# Altium schematic sheet cpu.SchDoc
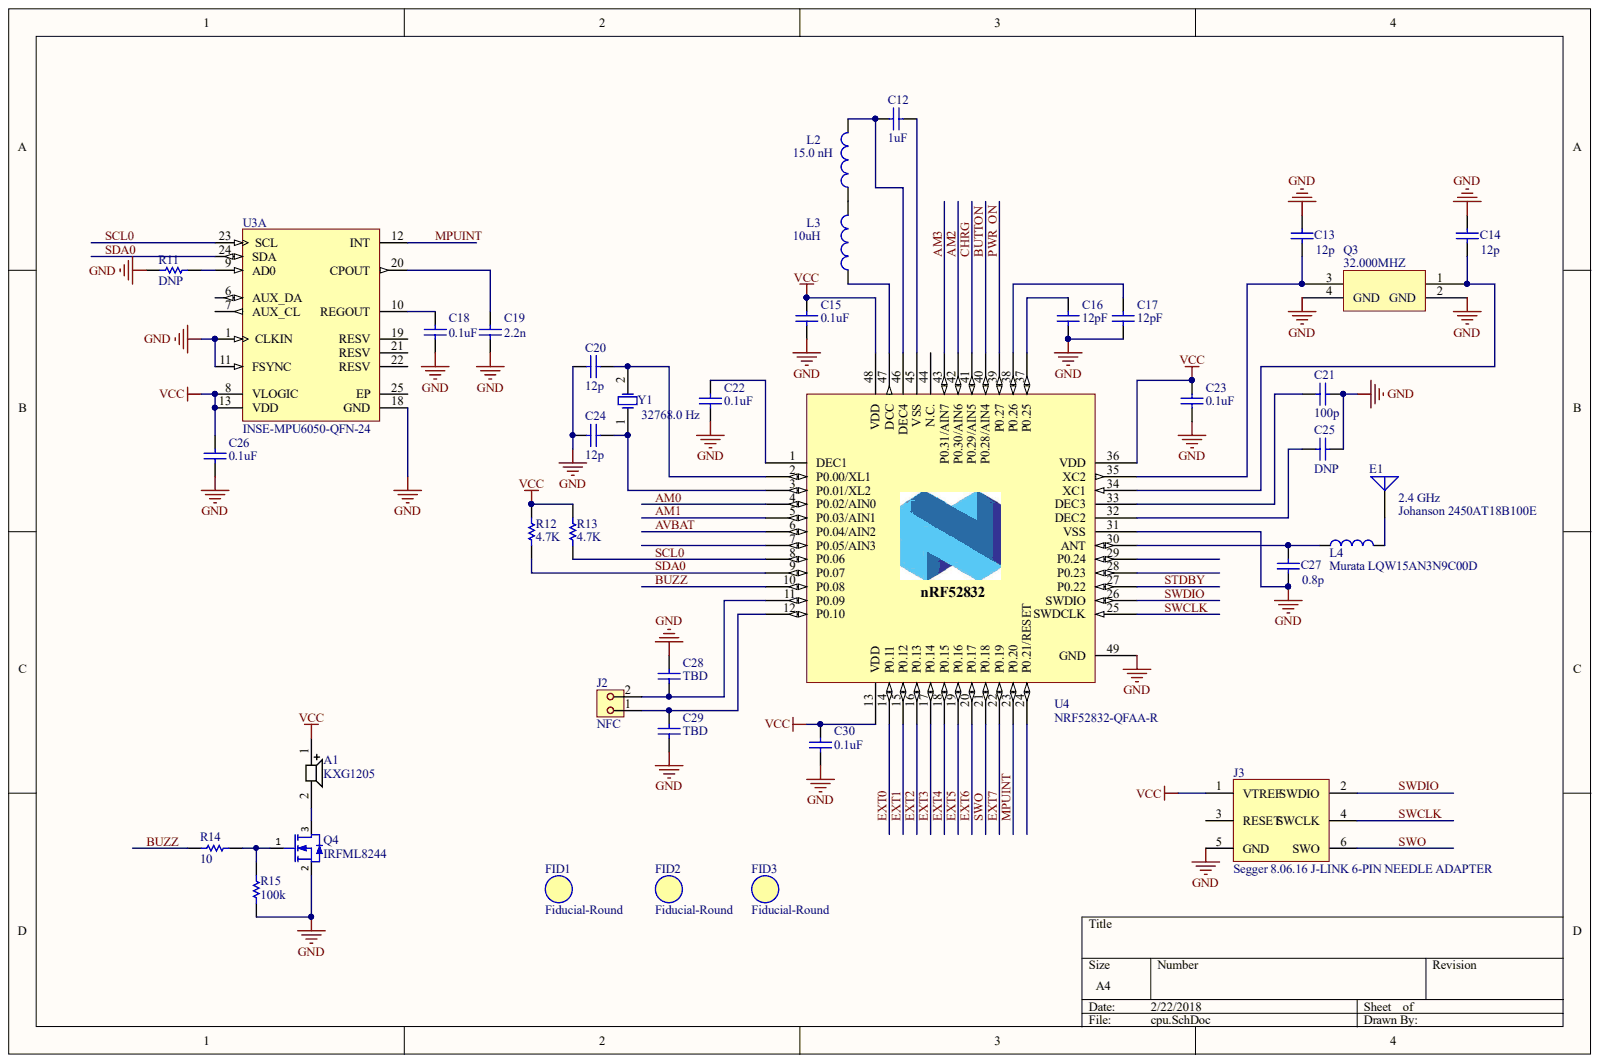
[diagram p0: cpu.SchDoc]

## Parts
A1  KXG1205  [PCB-wpqtvrz6t98vuyi79ns2-1]
C12  Capacitance: 1uF  [CAPC1608X90X35LL15T15]  (hidden comment: AVX Interconnect / Elco 06036D105KAT2A)
C13  Capacitance: 12p  [CAPC1005X56X25ML10T15]  (hidden comment: AVX Interconnect / Elco 04025A120JAT2A)
C14  Capacitance: 12p  [CAPC1005X56X25ML10T15]  (hidden comment: AVX Interconnect / Elco 04025A120JAT2A)
C15  Capacitance: 0.1uF  [CAPC1608X90X35LL15T15]  (hidden comment: AVX Interconnect / Elco 06036D105KAT2A)
C16  Capacitance: 12pF  [CAPC1608X90X35LL15T15]  (hidden comment: AVX Interconnect / Elco 06036D105KAT2A)
C17  Capacitance: 12pF  [CAPC1608X90X35LL15T15]  (hidden comment: AVX Interconnect / Elco 06036D105KAT2A)
C18  Capacitance: 0.1uF  [CAPC1608X90X35LL15T15]  (hidden comment: AVX Interconnect / Elco 06036D105KAT2A)
C19  Capacitance: 2.2n  [CAPC1608X90X35LL15T15]  (hidden comment: AVX Interconnect / Elco 06036D105KAT2A)
C20  Capacitance: 12p  [CAPC1005X56X25ML10T15]  (hidden comment: AVX Interconnect / Elco 04025A120JAT2A)
C21  Capacitance: 100p  [CAPC1608X90X35LL15T15]  (hidden comment: AVX Interconnect / Elco 06036D105KAT2A)
C22  Capacitance: 0.1uF  [CAPC1608X90X35LL15T15]  (hidden comment: AVX Interconnect / Elco 06036D105KAT2A)
C23  Capacitance: 0.1uF  [CAPC1608X90X35LL15T15]  (hidden comment: AVX Interconnect / Elco 06036D105KAT2A)
C24  Capacitance: 12p  [CAPC1005X56X25ML10T15]  (hidden comment: AVX Interconnect / Elco 04025A120JAT2A)
C25  Capacitance: DNP  [CAPC1608X90X35LL15T15]  (hidden comment: AVX Interconnect / Elco 06036D105KAT2A)
C26  Capacitance: 0.1uF  [CAPC1608X90X35LL15T15]  (hidden comment: AVX Interconnect / Elco 06036D105KAT2A)
C27  Capacitance: 0.8p  [CAPC1005X56X25ML10T15]  (hidden comment: AVX Interconnect / Elco 04025A120JAT2A)
C28  Capacitance: TBD  [CAPC1608X90X35LL15T15]  (hidden comment: AVX Interconnect / Elco 06036D105KAT2A)
C29  Capacitance: TBD  [CAPC1608X90X35LL15T15]  (hidden comment: AVX Interconnect / Elco 06036D105KAT2A)
C30  Capacitance: 0.1uF  [CAPC1608X90X35LL15T15]  (hidden comment: AVX Interconnect / Elco 06036D105KAT2A)
E1  Johanson 2450AT18B100E  Manufacturer Part Number: 2450AT18B100E  [PCB-uvsn8i53euurcjxwiz7p-1]
FID1  Fiducial-Round  [PCB-0wpew9alj3xmdtsx7yz2-1]
FID2  Fiducial-Round  [PCB-0wpew9alj3xmdtsx7yz2-1]
FID3  Fiducial-Round  [PCB-0wpew9alj3xmdtsx7yz2-1]
J2  NFC  [PCB-55llon6gpk2h6a06nz0l-1]
J3  Segger 8.06.16 J-LINK 6-PIN NEEDLE ADAPTER  [PCB-ya76044v471n1vts2f97-1]
L2  Johanson L-14C15NJV4T  [PCB-ck4de15kim8obyiadczt-1]  (value not drawn)
L3  value 10uH  [INDC2012X14N]  (hidden comment: Murata LQM21FN100M70L)
L4  Murata LQW15AN3N9C00D  Manufacturer Part Number: LQW15AN3N9C00D  [PCB-5jqft90mjn5qosal9tw7-1]
Q3  32.000MHZ  [PCB-fy0x3g1cwkm4xqo4jinq-1]
Q4  IRFML8244  [PCB-2559974-1]
R11  Resistance: DNP  [RESC1609X50X30ML10T20]  (hidden comment: Vishay Dale CRCW0603200KFKEA)
R12  Resistance: 4.7K  [RESC1609X50X30ML10T20]  (hidden comment: Vishay Dale CRCW0603200KFKEA)
R13  Resistance: 4.7K  [RESC1609X50X30ML10T20]  (hidden comment: Vishay Dale CRCW0603200KFKEA)
R14  Vishay Dale CRCW0603200KFKEA  [RESC1609X50X30ML10T20]  (value not drawn)
R15  Resistance: 100k  [RESC1609X50X30ML10T20]  (hidden comment: Vishay Dale CRCW0603200KFKEA)
U3  INSE-MPU6050-QFN-24  [INSE-QFN-24_V_Handsolder]  (part A)
U4  NRF52832-QFAA-R  [PCB-4fiqwekv961j0um5del2-1]
Y1  Abracon ABS07-32.768KHZ-7-T  [ABS07]  (value not drawn)

## Nets
GND: C13.2 C14.2 C15.1 C16.1 C17.1 C18.1 C19.1 C20.2 C21.1 C22.1 C23.1 C24.2 C25.1 C26.1 C27.1 C28.2 C29.1 C30.1 J3.5(GND) Q3.2(GND) Q3.4(GND) Q4.2 R11.1 R15.2 U3.1(CLKIN) U3.11(FSYNC) U3.18(GND) U4.31(VSS) U4.49(GND)
VCC: A1.1 C15.2 C23.2 C26.2 C30.2 J3.1(VTREF) R12.1 R13.1 U3.8(VLOGIC) U3.13(VDD) U4.13(VDD) U4.36(VDD) U4.48(VDD)
AM0: U4.4(P0.02/AIN0)
AM1: U4.5(P0.03/AIN1)
AM2: U4.42(P0.30/AIN6)
AM3: U4.43(P0.31/AIN7)
AVBAT: U4.6(P0.04/AIN2)
BUTTON: U4.40(P0.28/AIN4)
BUZZ: R14.1 U4.10(P0.08)
CHRG: U4.41(P0.29/AIN5)
EXT0: U4.14(P0.11)
EXT1: U4.15(P0.12)
EXT2: U4.16(P0.13)
EXT3: U4.17(P0.14)
EXT4: U4.18(P0.15)
EXT5: U4.19(P0.16)
EXT6: U4.20(P0.17)
EXT7: U4.22(P0.19)
MPUINT: U3.12(INT) U4.23(P0.20)
PWR_ON: U4.39(P0.27)
SCL0: R13.2 U3.23(SCL) U4.8(P0.06)
SDA0: R12.2 U3.24(SDA) U4.9(P0.07)
STDBY: U4.27(P0.22)
SWCLK: J3.4(SWCLK) U4.25(SWDCLK)
SWDIO: J3.2(SWDIO) U4.26(SWDIO)
SWO: J3.6(SWO) U4.21(P0.18)
NetA1_2: A1.2 Q4.3
NetC12_1: C12.1 U4.45(VSS)
NetC12_2: C12.2 L2.2 U4.46(DEC4)
NetC13_1: C13.1 Q3.3 U4.35(XC2)
NetC14_1: C14.1 Q3.1 U4.34(XC1)
NetC16_2: C16.2 U4.37(P0.25)
NetC17_2: C17.2 U4.38(P0.26)
NetC18_2: C18.2 U3.10(REGOUT)
NetC19_2: C19.2 U3.20(CPOUT)
NetC20_1: C20.1 U4.2(P0.00/XL1) Y1.2
NetC21_2: C21.2 U4.33(DEC3)
NetC22_2: C22.2 U4.1(DEC1)
NetC24_1: C24.1 U4.3(P0.01/XL2) Y1.1
NetC25_2: C25.2 U4.32(DEC2)
NetC27_2: C27.2 L4.1 U4.30(ANT)
NetC28_1: C28.1 J2.2 U4.11(P0.09)
NetC29_2: C29.2 J2.1 U4.12(P0.10)
NetE1_1: E1.1 L4.2
NetL2_1: L2.1 L3.2
NetL3_1: L3.1 U4.47(DCC)
NetQ4_1: Q4.1 R14.2 R15.1
NetR11_2: R11.2 U3.9(AD0)

Not connected: J3 3(RESET); U3 6(AUX_DA) 7(AUX_CL) 19(RESV) 21(RESV) 22(RESV) 25(EP); U4 7(P0.05/AIN3) 24(P0.21/RESET) 28(P0.23) 29(P0.24) 44(N.C.)

## Library data of the parts
A1 = CMP-872a4ebe890958ed-3: 8V PCB Mount Magnetic Buzzer, 92dBA Continuous; Supply Voltage (DC): 3.00 V (min); Component Kind: Standard; Library Name: CommunityVault
C12 C15-C19 C21-C23 C25 C26 C28-C30 = CMP-126022-2: CAP CER 1UF 6.3V 10% X5R 0603; Dielectric Material: Ceramic Multilayer; Insulation Resistance: 1e+11 Ω; Lead-Free Status: Lead Free; Capacitance Tolerance: ±10%; Case/Package: 0603; Component Kind: Standard; Dielectric Characteristic: X5R; Library Name: CommunityVault; Mounting Style: Surface Mount; Number of Pins: 2; OctopartID: 12fddbf79c04cd3a; Octopart Url: https://octopart.com/06036d105kat2a-avx+interconnect+%2F+elco-43935; REACH SVHC Compliance: No SVHC; RoHS: Compliant; Size-Height: 0.00086 m; Size-Length: 0.0016 m; Size-Thickness: 0.0008636 m; Size-Width: 0.00081 m; Voltage Rating (DC): 6.3 V
C13 C14 C20 C24 C27 = CMP-126060-4: AVX   04025A120JAT2A   CAP, MLCC, C0G/NP0, 12PF, 50V, 0402; CiivaID: 126060; Tolerance (%): ±5 %; Case Size/Dimension: 0402; Ciiva URL: https://ciiva.com/part/04025a120jat2a-126060; Component Kind: Standard; Dielectric: C0G/NP0; Library Name: CommunityVault; Manufacturer Part Number: 04025A120JAT2A; Rated Voltage: 50 V
E1 = CMP-1cded560de74c319-1: ANTENNA, CERAMIC, 2.45GHZ; Bandwidth: 100MHz; Frequency: 2.45GHz; Gain: -0.5dB; Impedance: 50ohm; Gain: -500 mdB; Power Rating: 3.00 W; Bandwidth: 100 MHz; Component Kind: Standard; Frequency: 2.45 GHz; Library Name: CommunityVault; OctopartID: 1cded560de74c319; Octopart URL: http://octopart.com/2450at18b100e-johanson-11855820; REACH SVHC Compliance: No SVHC; RoHS: Exempt
FID1-FID3 = CMP-p3mfnckk5kuwqukk1oh9-2: Round Fiducial Mark 1mm Pad, 1mm clearance; Component Kind: Standard; CustomComponentID: p3mfnckk5kuwqukk1oh9; Library Name: FavoritesLibrary
J2 = CMP-8fae46aeaafe5069-11: 2.54mm (.100") Pitch C-Grid III Header, Single Row, Vertical, 1 Circuits, Gold (Au) Plating; Manufacturer Part Number: 90120-0762; Contact Resistance: 0.02 ?; Current Rating: 3.0 A; Gender: Male; Glow Wire Compliant: Non-Compliant; Insulation Resistance: 5000000000.0 ?; Lead-Free Status: Lead Free; Number of Circuits: 1; Number of Contacts: 2; Pin Pitch: 0.00254 m; Voltage Rating (AC): 350.0 V; Component Kind: Standard; Contact Material: Brass; Contact Plating: Gold; Halogen Free Status: Not Reviewed; Housing Color: Black; Housing Material: Plastic; Library Name: CommunityVault; Lifecycle Status: Not Listed by Manufacturer; Mounting Style: Through Hole, Vertical; Number of Positions: 2; Number of Rows: 1; OctopartID: 8fae46aeaafe5069; Octopart URL: http://octopart.com/90120-0762-molex-655414; Orientation: Vertical; Packaging: Bag; RoHS: Compliant; Size-Height: 0.0025 m; Size-Length: 0.00508 m; Size-Width: 0.00254 m; Voltage Rating (DC): 350.0 V
J3 = CMP-fcd43101a04b2313-1: j-Link 6-Pin Needle Adapter; Component Kind: Standard; Library Name: CommunityVault; Octopart URL: http://octopart.com/8.06.16+j-link+6-pin+needle+adapter-segger-81754617
L2 = CMP-e23d2bf7be6f3dfa-1: Inductor RF Chip Multi-Layer 15nH 5% 100MHz 8Q-Factor Ceramic 300mA 450mOhm DCR 0603 T/R; Inductance: 15.0 nH; Q-Factor: 8.0; Self Resonant Frequency: 2.90 GHz; Case/Package: 0603, 1608; Component Kind: Standard; Current Rating: 300 mA; Library Name: CommunityVault; Manufacturer Part Number: L-14C15NJV4T; Number of Pins: 2; OctopartID: e23d2bf7be6f3dfa; Octopart URL: http://octopart.com/l-14c15njv4t-johanson-12613940; Packaging: Cut Tape (CT); REACH SVHC Compliance: No SVHC; RoHS: Exempt
L3 = CMP-1b7ed271d7e0c4b9-1: Inductor Power Chip Shielded Multi-Layer 10uH 20% 1MHz Ferrite 100mA 780mOhm DCR 0805 Embossed T/R; Component Kind: Standard; Library Name: CommunityVault
L4 = CMP-5b209227e32b84e7-1: LQW15 Series 0402 3.9 nH ±0.2 nH 750mA 0.07Ohm SMT Unshielded Wirewound Inductor; Inductance: 3.90 nH; Lead-Free Status: Lead Free; Case/Package: 0402, 1005; Component Kind: Standard; Library Name: CommunityVault; Lifecycle Status: Active; OctopartID: 5b209227e32b84e7; Octopart URL: http://octopart.com/lqw15an3n9c00d-murata-892269; RoHS: Compliant
Q3 = CMP-ff063720fb3c20c1-2: Crystal 32MHz ??20ppm (Tol) ??20ppm (Stability) 8pF FUND 100Ohm 4-Pin CSMD T/R; Manufacturer Part Number: ABM12-32.000MHZ-B2X-T3; Frequency: 32.0 MHz; Lead-Free Status: Lead Free; Load Capacitance: 8.00 pF; Component Kind: Standard; Library Name: CommunityVault; Number of Pins: 4; OctopartID: ff063720fb3c20c1; Octopart URL: http://octopart.com/abm12-32.000mhz-b2x-t3-abracon-19669340; RoHS: Compliant
Q4 = CMP-2559974-6: Trans MOSFET N-CH 25V 5.8A 3-Pin SOT-23 T/R; Lead-Free Status: Lead Free; Polarity: N-Channel; Power Dissipation: 1.25 W; Component Kind: Standard; Library Name: CommunityVault; Lifecycle Status: Active; Mounting Style: Surface Mount; Number of Pins: 3; OctopartID: 10b1d89ad6afcf76; Octopart Url: https://octopart.com/irfml8244trpbf-international+rectifier-18998136; Packaging: Cut Tape (CT); Part Family: IRFML8244; REACH SVHC Compliance: No SVHC; RoHS: Compliant
R11-R13 R15 = CMP-1857245-4: RES SMD 200K OHM 1% 1/10W 0603; Lead-Free Status: Lead Free; Power Rating: 0.1 W; Temperature Coefficient: 100.0 ppm/°C; Voltage Rating (DC): 75.0 V; Case/Package: 0603; Component Kind: Standard; Library Name: CommunityVault; Mounting Style: Surface Mount; Number of Pins: 2; OctopartID: 353afe6f23fdeafd; Octopart Url: https://octopart.com/crcw0603200kfkea-vishay-39793569; REACH SVHC Compliance: No SVHC; Resistance Tolerance: ±1%; RoHS: Compliant; Size-Height: 0.00045 m; Size-Length: 0.00155 m; Size-Width: 0.00085 m
R14 = CMP-1857245-4: RES SMD 200K OHM 1% 1/10W 0603; Lead-Free Status: Lead Free; Power Rating: 0.1 W; Resistance: 10; Temperature Coefficient: 100.0 ppm/°C; Voltage Rating (DC): 75.0 V; Case/Package: 0603; Component Kind: Standard; Library Name: CommunityVault; Mounting Style: Surface Mount; Number of Pins: 2; OctopartID: 353afe6f23fdeafd; Octopart Url: https://octopart.com/crcw0603200kfkea-vishay-39793569; REACH SVHC Compliance: No SVHC; Resistance Tolerance: ±1%; RoHS: Compliant; Size-Height: 0.00045 m; Size-Length: 0.00155 m; Size-Width: 0.00085 m
U3 = CMP-1172158-15: 3 Axis Gyro Sensor integrate 3 Axis G-Sensor; Component Kind: Standard; Library Name: CommunityVault; Number of Pins: 24; Packaging: Cut Tape (CT); REACH SVHC Compliance: No SVHC; RoHS: Compliant
U4 = CMP-1e56d4d0c871c191-11: Qfn48 Packet 512kb Flash / 64kb Ram; Component Kind: Standard; Library Name: CommunityVault
Y1 = CMP-0392ca922f93dc55-3: ABS07 Series 32.768 kHz ±20 ppm 7 pF -40 to +85 °C SMT Low Profile Crystal; Equivalent Series Resistance (ESR): 70000.0 Ω; Frequency: 32768.0 Hz; Lead-Free Status: Lead Free; Load Capacitance: 7e-12 F; Component Kind: Standard; Library Name: CommunityVault; Manufacturer Part Number: ABS07-32.768KHZ-7-T; Mounting Style: Surface Mount; Number of Pins: 2; OctopartID: 0392ca922f93dc55; Octopart URL: http://octopart.com/abs07-32.768khz-7-t-abracon-657767; Packaging: Tape & Reel (TR), Cut Tape (CT); REACH SVHC Compliance: No SVHC; RoHS: Compliant; Size-Height: 0.0009 m
